# Altium schematic sheet Sheet1.SchDoc
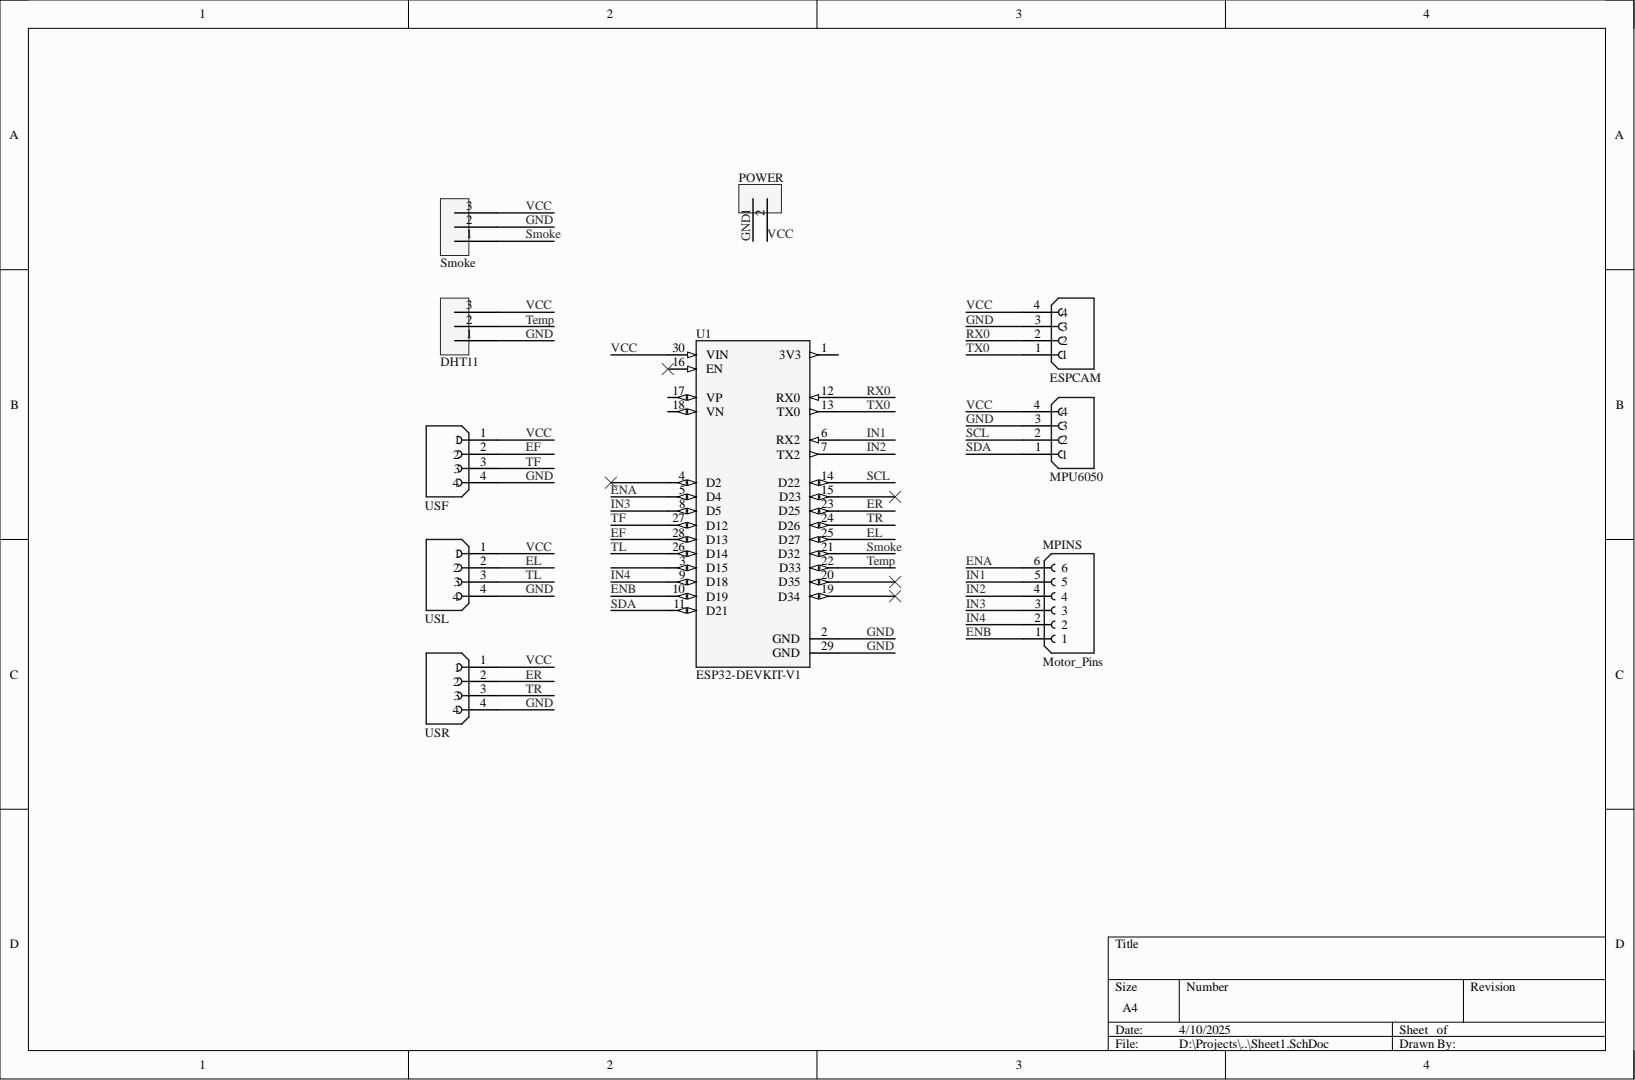
[diagram p0: Sheet1.SchDoc]

## Parts
DHT11  DHT11  [Connector]
ESPCAM  ESPCAM  [SULLINS_PPTC041LFBN-RC]
MPINS  Motor_Pins  [SULLINS_PPTC061LFBN-RC]
MPU  MPU6050  [SULLINS_PPTC041LFBN-RC]
POWER  POWER  [2x1conn]
Smoke  MQ2  [Connector]  (value not drawn)
U1  ESP32-DEVKIT-V1  [MODULE_ESP32_DEVKIT_V1]
USF  USF  [SULLINS_PPTC041LFBN-RC]
USL  USL  [SULLINS_PPTC041LFBN-RC]
USR  USR  [SULLINS_PPTC041LFBN-RC]

## Nets
EF: U1.28(D13) USF.2
EL: U1.25(D27) USL.2
ENA: MPINS.6 U1.5(D4)
ENB: MPINS.1 U1.10(D19)
ER: U1.23(D25) USR.2
GND: DHT11.1 ESPCAM.3 MPU.3 POWER.1 Smoke.2 U1.2(GND) U1.29(GND) USF.4 USL.4 USR.4
IN1: MPINS.5 U1.6(RX2)
IN2: MPINS.4 U1.7(TX2)
IN3: MPINS.3 U1.8(D5)
IN4: MPINS.2 U1.9(D18)
RX0: ESPCAM.2 U1.12(RX0)
SCL: MPU.2 U1.14(D22)
SDA: MPU.1 U1.11(D21)
Smoke: Smoke.1 U1.21(D32)
Temp: DHT11.2 U1.22(D33)
TF: U1.27(D12) USF.3
TL: U1.26(D14) USL.3
TR: U1.24(D26) USR.3
TX0: ESPCAM.1 U1.13(TX0)
VCC: DHT11.3 ESPCAM.4 MPU.4 POWER.2 Smoke.3 U1.30(VIN) USF.1 USL.1 USR.1

Not connected: U1 1(3V3) 3(D15) 4(D2) 15(D23) 16(EN) 17(VP) 18(VN) 19(D34) 20(D35)

## Library data of the parts
DHT11 Smoke = Component_1: Component Kind: Standard; Library Name: Schlib1.SchLib
ESPCAM MPU USF USL USR = PPTC041LFBN-RC: 4 Position Header Connector 0.100 (2.54mm) Through Hole Tin; MF: Sullins Connector; Check_prices: https://www.snapeda.com/parts/PPTC041LFBN-RC/Sullins/view-part/?ref=eda; Component Kind: Standard; Library Name: 4x1conn.SchLib; MP: PPTC041LFBN-RC; Package: None; SnapEDA_Link: https://www.snapeda.com/parts/PPTC041LFBN-RC/Sullins/view-part/?ref=snap
MPINS = PPTC061LFBN-RC: 6 Position Total Single Row 2.54 mm Pitch Straight Female Header; MF: Sullins Connector Solutions; MP: PPTC061LFBNRC; Check_prices: https://www.snapeda.com/parts/PPTC061LFBNRC/Sullins/view-part/?ref=eda; Component Kind: Standard; Library Name: 6x1conn.SchLib; Package: None; SnapEDA_Link: https://www.snapeda.com/parts/PPTC061LFBNRC/Sullins/view-part/?ref=snap
POWER = conn2: Component Kind: Standard; Library Name: Schlib1.SchLib
U1 = ESP32-DEVKIT-V1: Dual core, Wi-Fi: 2.4 GHz up to 150 Mbits/s,BLE (Bluetooth Low Energy) and legacy Bluetooth, 32 bits, Up to 240 MHz; MF: Do it; Check_prices: https://www.snapeda.com/parts/ESP32-DEVKIT-V1/Do+it/view-part/?ref=eda; Component Kind: Standard; Library Name: ESP32-DEVKIT-V1.SchLib; MP: ESP32-DEVKIT-V1; Package: None; SnapEDA_Link: https://www.snapeda.com/parts/ESP32-DEVKIT-V1/Do+it/view-part/?ref=snap
